# Altium schematic sheet Sheet_1_MCU.SchDoc(Sheet_1_MCU)
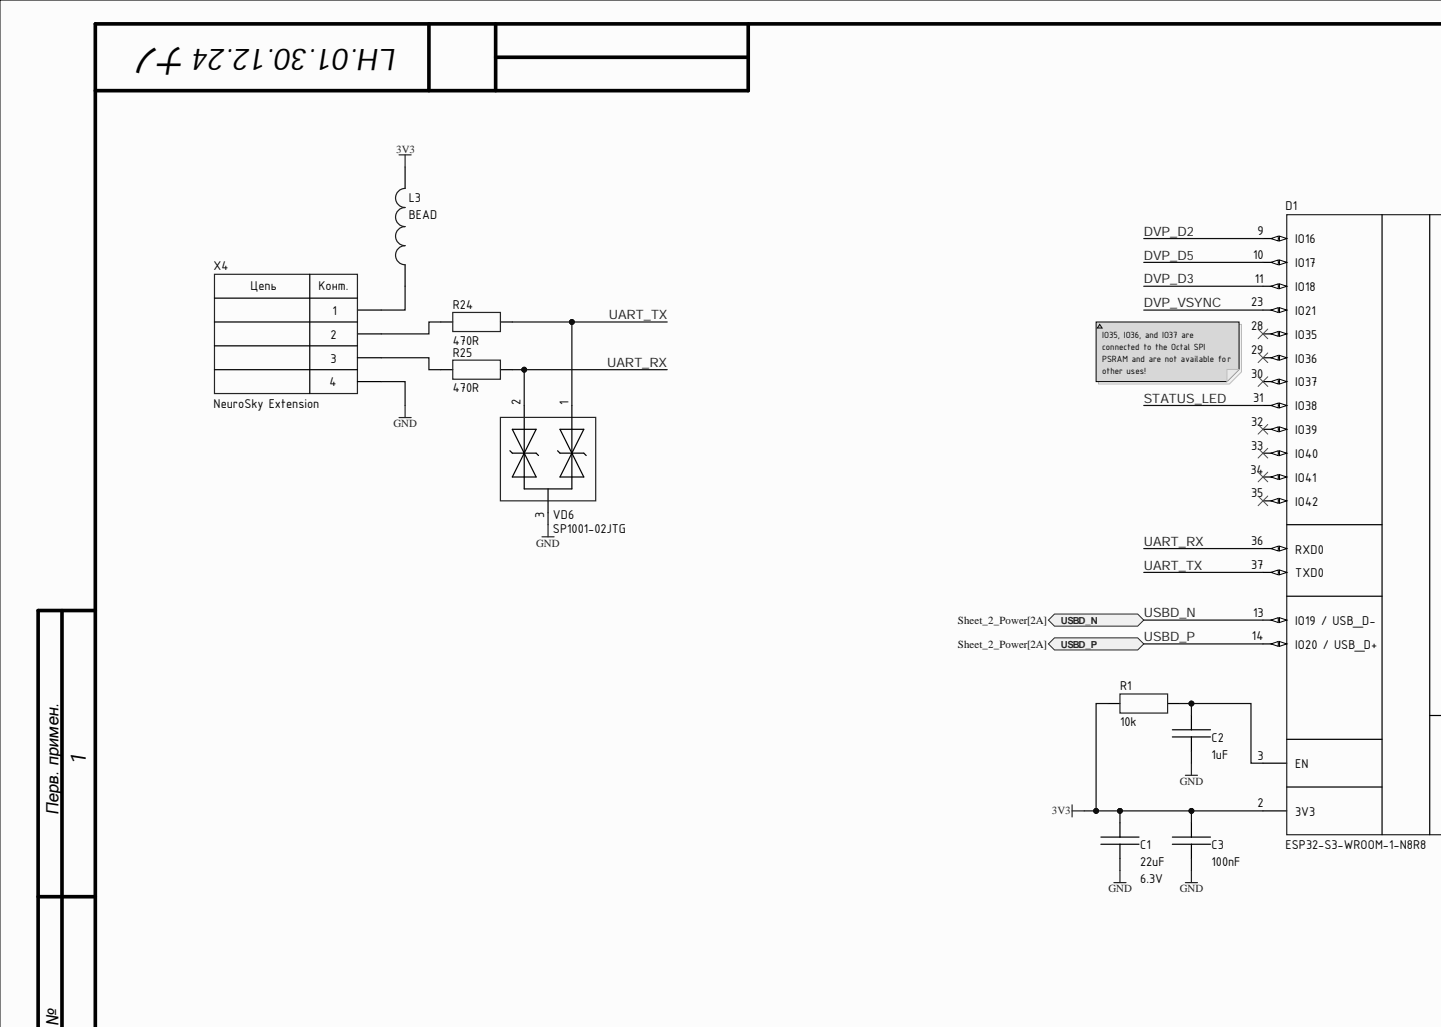
[diagram p0: Sheet_1_MCU.SchDoc, top left]
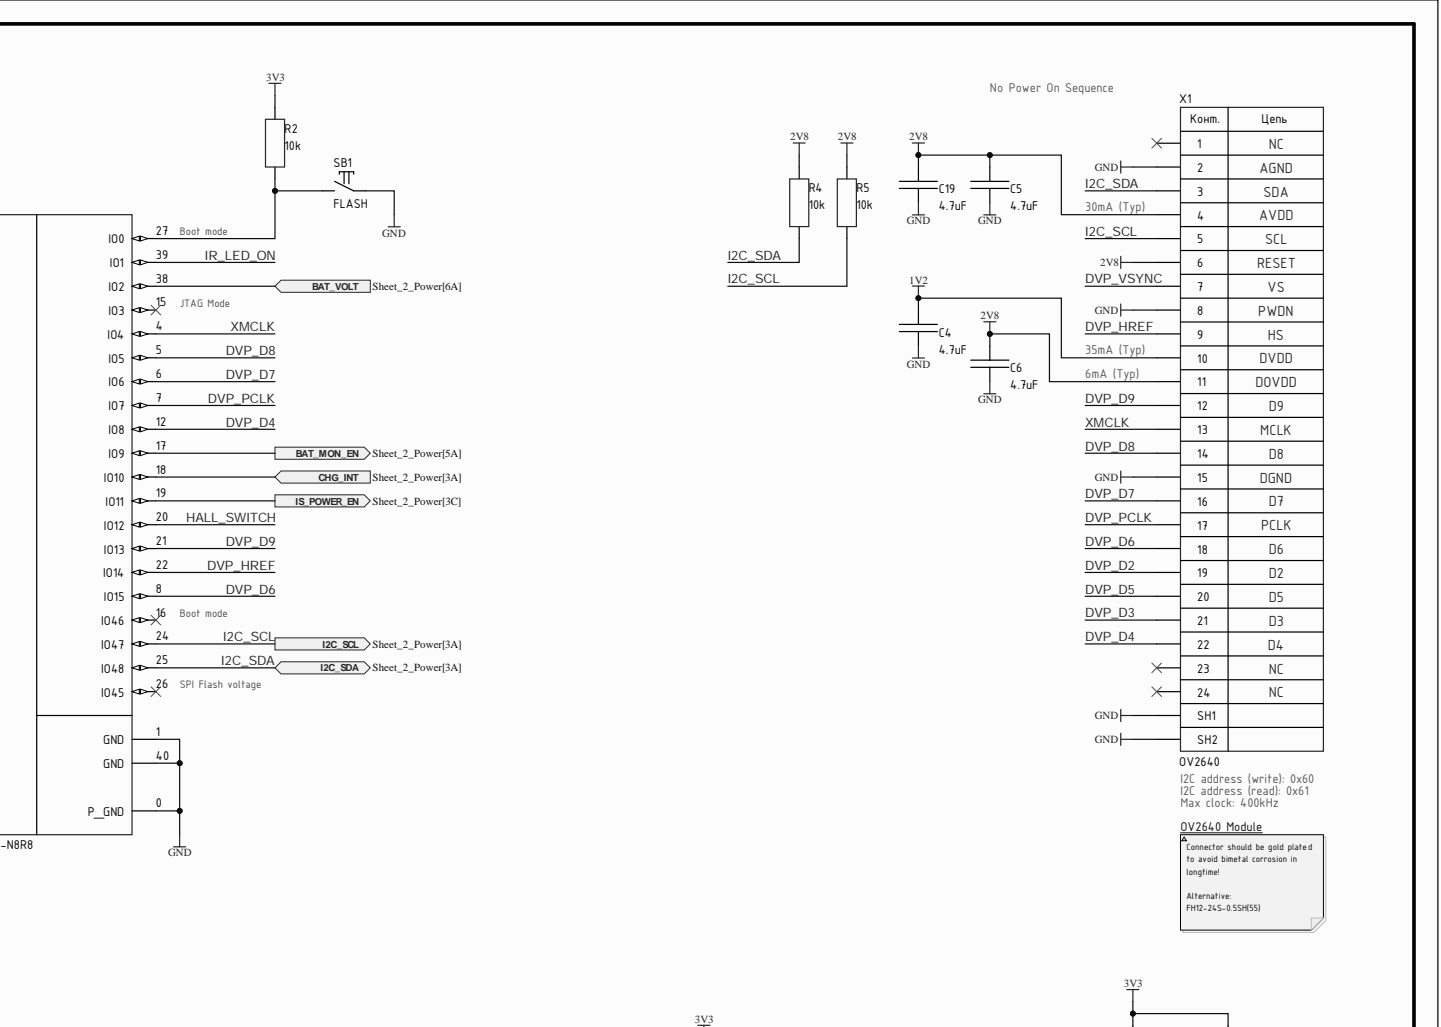
[diagram p1: Sheet_1_MCU.SchDoc, top right]
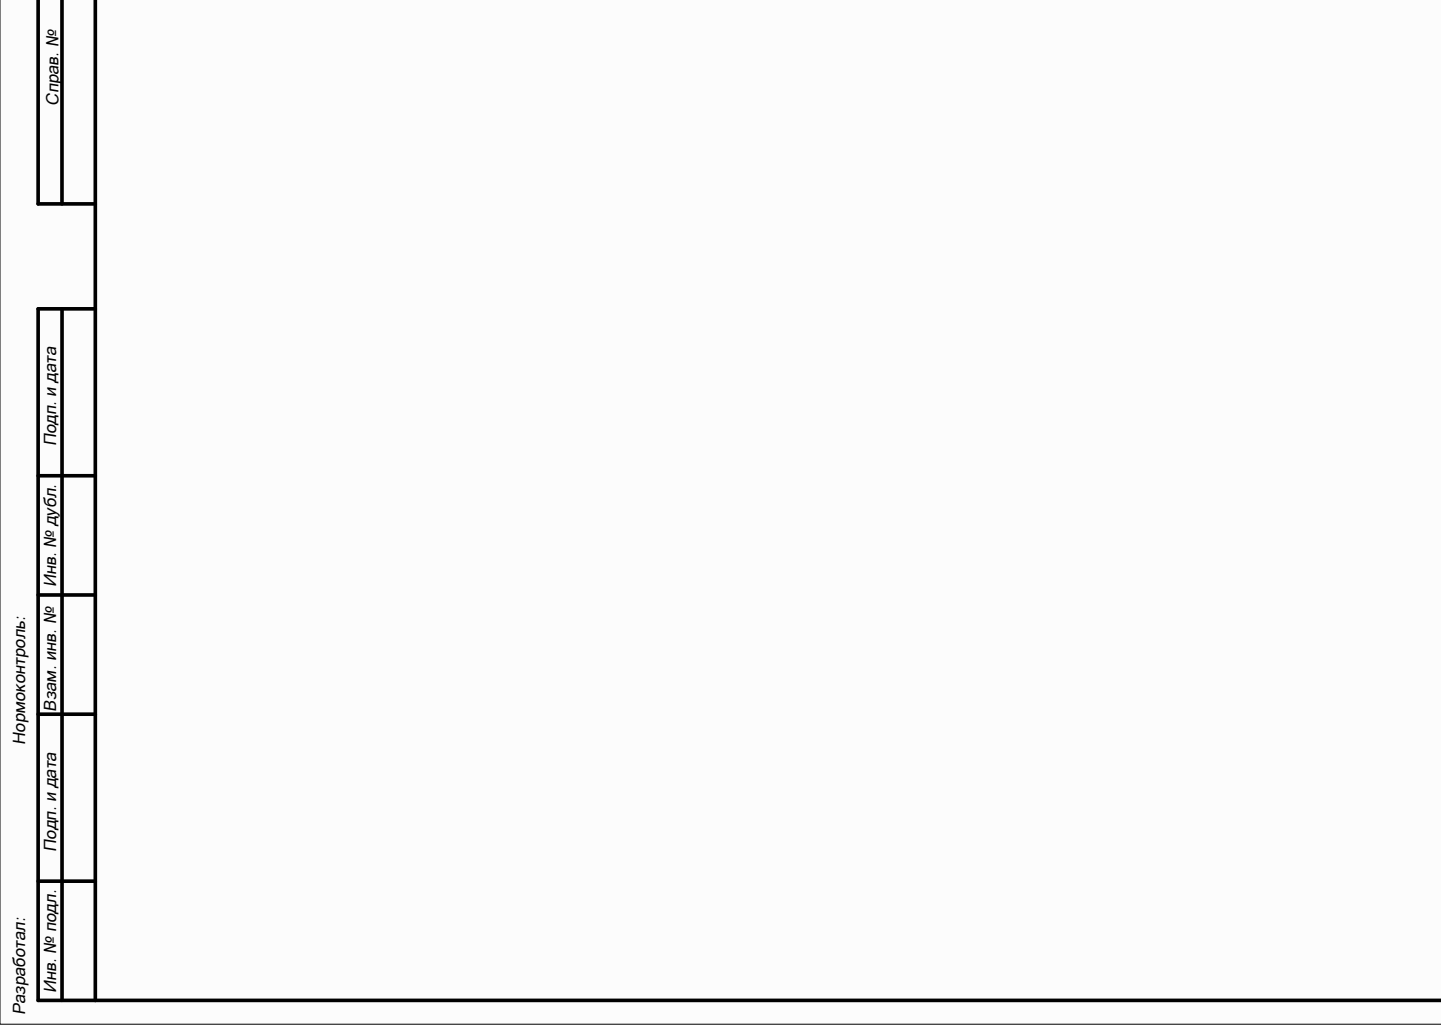
[diagram p2: Sheet_1_MCU.SchDoc, bottom left]
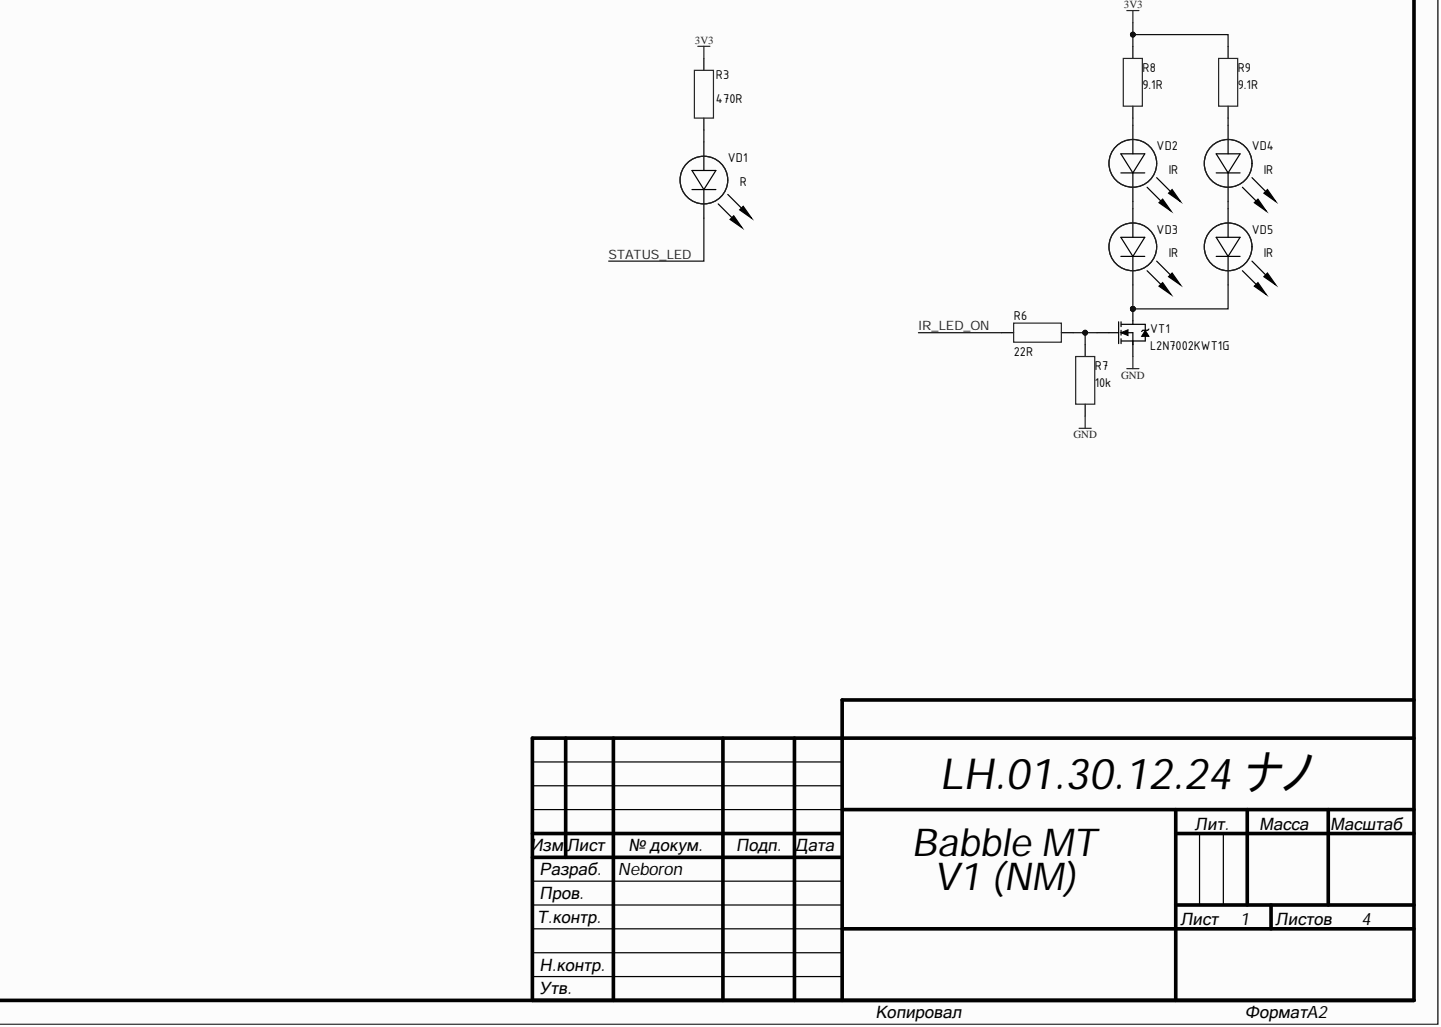
[diagram p3: Sheet_1_MCU.SchDoc, bottom right]

## Parts
C1  value 22uF  Voltage: 6.3V  [CAP: 0603]  (hidden comment: Ceramic capacitor, 22uF, X5R, ±10%, 6.3V, 0603, -55...+125°C)
C2  value 1uF  [CAP: 0402]  (hidden comment: Ceramic capacitor, 1uF, X7R, ±10%, 6.3V, 0402, -55...+125°C)
C3  value 100nF  [CAP: 0402]  (hidden comment: Ceramic capacitor, 100nF, X7R, ±10%, 16V, 0402, -55...+125°C)
C4  value 4.7uF  [CAP: 0402]  (hidden comment: Ceramic capacitor, 4.7uF, X7R, ±10%, 16V, 0402, -55...+125°C)
C5  value 4.7uF  [CAP: 0402]  (hidden comment: Ceramic capacitor, 4.7uF, X7R, ±10%, 16V, 0402, -55...+125°C)
C6  value 4.7uF  [CAP: 0402]  (hidden comment: Ceramic capacitor, 4.7uF, X7R, ±10%, 16V, 0402, -55...+125°C)
C19  value 4.7uF  [CAP: 0402]  (hidden comment: Ceramic capacitor, 4.7uF, X7R, ±10%, 16V, 0402, -55...+125°C)
D1  Wi-Fi module, WiFi 802.11 b/g/n (802.11n up to 150 Mbps) / Bluetooth v4.2 BR/EDR and BLE specification, 32 bit, SD card, UART, SPI, SDIO, I2C, LED PWM, Motor PWM, I2S, IR, pulse counter, GPIO, capacitive touch sensor, ADC, DAC, -40...+85°C, 18x25.5x3.1  [MOD: ESP32-S3-WROOM-1]  (value not drawn)
L3  value BEAD  [IND: 0402]  (hidden comment: Fixed inductor, BEAD, ±25%, 0402, 900mA, -55...+125°C)
R1  value 10k  [RES: 0402]  (hidden comment: Resistor film, 10k, ±5%, 0402, 0.063W, -55...+125°C)
R2  value 10k  [RES: 0402]  (hidden comment: Resistor film, 10k, ±5%, 0402, 0.063W, -55...+125°C)
R3  value 470R  [RES: 0402]  (hidden comment: Resistor film, 470R, ±5%, 0402, 0.063W, -55...+125°C)
R4  value 10k  [RES: 0402]  (hidden comment: Resistor film, 10k, ±5%, 0402, 0.063W, -55...+125°C)
R5  value 10k  [RES: 0402]  (hidden comment: Resistor film, 10k, ±5%, 0402, 0.063W, -55...+125°C)
R6  value 22R  [RES: 0402]  (hidden comment: Resistor film, 22R, ±5%, 0402, 0.063W, -55...+125°C)
R7  value 10k  [RES: 0402]  (hidden comment: Resistor film, 10k, ±5%, 0402, 0.063W, -55...+125°C)
R8  value 9.1R  [RES: 0603]  (hidden comment: Resistor film, 9.1R, ±5%, 0603, 0.1W, -55...+125°C)
R9  value 9.1R  [RES: 0603]  (hidden comment: Resistor film, 9.1R, ±5%, 0603, 0.1W, -55...+125°C)
R24  value 470R  [RES: 0402]  (hidden comment: Resistor film, 470R, ±5%, 0402, 0.063W, -55...+125°C)
R25  value 470R  [RES: 0402]  (hidden comment: Resistor film, 470R, ±5%, 0402, 0.063W, -55...+125°C)
SB1  CommentText: FLASH  [BUT: TS-1186F-B-A]  (hidden comment: Tactile button, TS-1186F)
VD1  Color: R  [LED: 0402R]  (hidden comment: LED, Green, *, *, *, -55...+150°C)
VD2  Color: IR  [LED: 1206R]  (hidden comment: LED, 850...858nm, 35mA, 1.5V, 1206, -55...+150°C)
VD3  Color: IR  [LED: 1206R]  (hidden comment: LED, 850...858nm, 35mA, 1.5V, 1206, -55...+150°C)
VD4  Color: IR  [LED: 1206R]  (hidden comment: LED, 850...858nm, 35mA, 1.5V, 1206, -55...+150°C)
VD5  Color: IR  [LED: 1206R]  (hidden comment: LED, 850...858nm, 35mA, 1.5V, 1206, -55...+150°C)
VD6  PartNumber: SP1001-02JTG  [SC-70]  (hidden comment: TVS, 5.5V, 2A, , , SC-70-3, -55...+150°C)
VT1  PartNumber: L2N7002KWT1G  [SC-70]  (hidden comment: MOSFET N-channel transistor, 60V, 380mA, , 300mW, 2.3Ohm, 34pF, SC-70, -55...+150°C)
X1  CommentText: OV2640  [CON: FFC/FPC-24-0.5(Hirose)]  (hidden comment: Connector, 24pin, 0.5mm, FFC/FPC, 0.5A)
X4  CommentText: NeuroSky Extension  [CON: SM04B-GHS]  (hidden comment: Connector, 4pin, 1.25mm, 50VDC, 1A)

## Ports
BAT_MON_EN, BAT_VOLT, CHG_INT, I2C_SCL, I2C_SDA, IS_POWER_EN, USBD_N, USBD_P

## Nets
1V2: C4.1 X1.10
2V8: C5.1 C6.1 C19.1 R4.1 R5.1 X1.4 X1.6 X1.11
3V3: C1.2 C3.2 D1.2(3V3) L3.1 R1.2 R2.1 R3.2 R8.2 R9.2
GND: C1.1 C2.1 C3.1 C4.2 C5.2 C6.2 C19.2 D1.0(P_GND) D1.1(GND) D1.40(GND) R7.2 SB1.1 VD6.3 VT1.3 X1.2 X1.8 X1.15 X1.SH1 X1.SH2 X4.4
BAT_MON_EN: D1.17(IO9) ; port BAT_MON_EN
BAT_VOLT: D1.38(IO2) ; port BAT_VOLT
CHG_INT: D1.18(IO10) ; port CHG_INT
DVP_D2: D1.9(IO16) X1.19
DVP_D3: D1.11(IO18) X1.21
DVP_D4: D1.12(IO8) X1.22
DVP_D5: D1.10(IO17) X1.20
DVP_D6: D1.8(IO15) X1.18
DVP_D7: D1.6(IO6) X1.16
DVP_D8: D1.5(IO5) X1.14
DVP_D9: D1.21(IO13) X1.12
DVP_HREF: D1.22(IO14) X1.9
DVP_PCLK: D1.7(IO7) X1.17
DVP_VSYNC: D1.23(IO21) X1.7
HALL_SWITCH: D1.20(IO12)
I2C_SCL: D1.24(IO47) R5.2 X1.5 ; port I2C_SCL
I2C_SDA: D1.25(IO48) R4.2 X1.3 ; port I2C_SDA
IR_LED_ON: D1.39(IO1) R6.2
IS_POWER_EN: D1.19(IO11) ; port IS_POWER_EN
STATUS_LED: D1.31(IO38) VD1.1
UART_RX: D1.36(RXD0) R25.1 VD6.2
UART_TX: D1.37(TXD0) R24.1 VD6.1
USBD_N: D1.13(IO19) ; port USBD_N
USBD_P: D1.14(IO20) ; port USBD_P
XMCLK: D1.4(IO4) X1.13
NetC2_2: C2.2 D1.3(EN) R1.1
NetD1_27: D1.27(IO0) R2.2 SB1.2
NetL3_2: L3.2 X4.1
NetR3_1: R3.1 VD1.2
NetR6_1: R6.1 R7.1 VT1.1
NetR8_1: R8.1 VD2.2
NetR9_1: R9.1 VD4.2
NetR24_2: R24.2 X4.2
NetR25_2: R25.2 X4.3
NetVD2_1: VD2.1 VD3.2
NetVD3_1: VD3.1 VD5.1 VT1.2
NetVD4_1: VD4.1 VD5.2

Not connected: D1 15(IO3) 16(IO46) 26(IO45) 28(IO35) 29(IO36) 30(IO37) 32(IO39) 33(IO40) 34(IO41) 35(IO42); X1 1 23 24

## Library data of the parts
C1 = Ceramic_0603: Manufacturer: Any; Component Kind: Standard; Library Name: capacitor.IntLib; Package: 0603; Temperature: -55...+125°C; Tolerance: ±10%; TypeCapacitor: Ceramic capacitor; TypeDielectric: X5R; ValueManufacturerPartNumber: Ceramic capacitor, 22uF, X5R, ±10%, 6.3V, 0603, -55...+125°C
C2-C6 C19 = Ceramic_0402: Manufacturer: Any; Component Kind: Standard; Library Name: capacitor.IntLib; Package: 0402; Temperature: -55...+125°C; Tolerance: ±10%; TypeCapacitor: Ceramic capacitor; TypeDielectric: X7R
  C2: ValueManufacturerPartNumber: Ceramic capacitor, 1uF, X7R, ±10%, 6.3V, 0402, -55...+125°C; Voltage: 6.3V
  C3: ValueManufacturerPartNumber: Ceramic capacitor, 100nF, X7R, ±10%, 16V, 0402, -55...+125°C; Voltage: 16V
  C4-C6 C19: ValueManufacturerPartNumber: Ceramic capacitor, 4.7uF, X7R, ±10%, 16V, 0402, -55...+125°C; Voltage: 16V
D1 = ESP32-S3-WROOM-1-N8R8: Wi-Fi; Manufacturer: Espressif Systems; Core: 32 bit; Component Kind: Standard; ComponentType: Wi-Fi module; HelpURL: https://www.espressif.com/sites/default/files/documentation/esp32-s3-wroom-1_wroom-1u_datasheet_en.pdf; Library Name: module.IntLib; Package: 18x25.5x3.1; PartNumber: ESP32-S3-WROOM-1-N8R8; Temperature: -40...+85°C; TypeInterface: SD card, UART, SPI, SDIO, I2C, LED PWM, Motor PWM, I2S, IR, pulse counter, GPIO, capacitive touch sensor, ADC, DAC; TypeStandart: WiFi 802.11 b/g/n (802.11n up to 150 Mbps) / Bluetooth v4.2 BR/EDR and BLE specification; ValueManufacturerPartNumber: Wi-Fi module, WiFi 802.11 b/g/n (802.11n up to 150 Mbps) / Bluetooth v4.2 BR/EDR and BLE specification, 32 bit, SD card, UART, SPI, SDIO, I2C, LED PWM, Motor PWM, I2S, IR, pulse counter, GPIO, capacitive touch sensor, ADC, DAC, -40...+85°C, 18x25.5x3.1
L3 = Inductor_0402: Manufacturer: Murata Electronics; PartNumber: BLM15PX601SN1D; Component Kind: Standard; HelpURL: https://www.lcsc.com/datasheet/lcsc_datasheet_2304140030_Murata-Electronics-BLM15PX601SN1D_C160977.pdf; Library Name: inductor.IntLib; MaxCurrent: 900mA; Package: 0402; Temperature: -55...+125°C; Tolerance: ±25%; TypeInductor: Fixed inductor; ValueManufacturerPartNumber: Fixed inductor, BEAD, ±25%, 0402, 900mA, -55...+125°C
R1 R2 R4-R7 = Resistor_0402: Manufacturer: Any; Component Kind: Standard; Library Name: resistor.IntLib; Package: 0402; Power: 0.063W; Temperature: -55...+125°C; Tolerance: ±5%; TypeResistor: Resistor film
  R1 R2 R4 R5 R7: ValueManufacturerPartNumber: Resistor film, 10k, ±5%, 0402, 0.063W, -55...+125°C
  R6: ValueManufacturerPartNumber: Resistor film, 22R, ±5%, 0402, 0.063W, -55...+125°C
R3 R24 R25 = Resistor_0402: Manufacturer: Yageo; PartNumber: RC0402JR-07100RL; Component Kind: Standard; HelpURL: https://www.digikey.com/product-detail/en/yageo/RC0402JR-07100RL/311-100JRCT-ND/729362; Library Name: resistor.IntLib; Package: 0402; Power: 0.063W; Temperature: -55...+125°C; Tolerance: ±5%; TypeResistor: Resistor film; ValueManufacturerPartNumber: Resistor film, 470R, ±5%, 0402, 0.063W, -55...+125°C
R8 R9 = Resistor_0603: Manufacturer: Any; Component Kind: Standard; Library Name: resistor.IntLib; Package: 0603; Power: 0.1W; Temperature: -55...+125°C; Tolerance: ±5%; TypeResistor: Resistor film; ValueManufacturerPartNumber: Resistor film, 9.1R, ±5%, 0603, 0.1W, -55...+125°C
SB1 = Button_tactile: Manufacturer: XKB Connection; PartNumber: TS-1186F-C-A; Component Kind: Standard; HelpURL: https://www.lcsc.com/product-detail/Tactile-Switches_XKB-Connection-TS-1186F-C-A_C908471.html; Library Name: electromechanics.IntLib; Package: TS-1186F; TypeButton: Tactile button; ValueManufacturerPartNumber: Tactile button, TS-1186F
VD1 = LED_single: ColorParameter: Green; Component Kind: Standard; Library Name: diode.IntLib; Temperature: -55...+150°C; TypeDiode: LED; ValueManufacturerPartNumber: LED, Green, *, *, *, -55...+150°C
VD2-VD5 = LED_single: Manufacturer: XINGLIGHT; PartNumber: XL-3216HIRC-850; ColorParameter: 850...858nm; Component Kind: Standard; Current: 35mA; HelpURL: https://www.lcsc.com/datasheet/lcsc_datasheet_2409271733_XINGLIGHT-XL-3216HIRC-850_C965891.pdf; Library Name: diode.IntLib; Package: 1206; Temperature: -55...+150°C; TypeDiode: LED; ValueManufacturerPartNumber: LED, 850...858nm, 35mA, 1.5V, 1206, -55...+150°C; VoltageDrop: 1.5V
VD6 = TVS_array: Manufacturer: Littelfuse; Component Kind: Standard; Current: 2A; HelpURL: https://www.lcsc.com/datasheet/lcsc_datasheet_2410010304_Littelfuse-SP1001-02JTG_C151300.pdf; Library Name: diode.IntLib; Package: SC-70-3; Temperature: -55...+150°C; TypeDiode: TVS; ValueManufacturerPartNumber: TVS, 5.5V, 2A, , , SC-70-3, -55...+150°C; Voltage: 5.5V
VT1 = MOSFET_N_123: Manufacturer: LRC; Component Kind: Standard; CurrentDS: 380mA; HelpURL: https://www.lcsc.com/datasheet/lcsc_datasheet_2111041830_LRC-L2N7002KWT1G_C2912011.pdf; InputCapacitor: 34pF; Library Name: transistor.IntLib; MaxPower: 300mW; Package: SC-70; RDS: 2.3Ohm; Temperature: -55...+150°C; TypeTransistor: MOSFET N-channel transistor; ValueManufacturerPartNumber: MOSFET N-channel transistor, 60V, 380mA, , 300mW, 2.3Ohm, 34pF, SC-70, -55...+150°C; VoltageDS: 60V
X1 = Connector_FFC_24 (TF31-24S-0.5SH(800)): Manufacturer: Hirose; Component Kind: Standard; CurrentMax: 0.5A; HelpURL: https://www.lcsc.com/datasheet/lcsc_datasheet_2410291517_HRS-Hirose-TF31-24S-0-5SH-800_C3169854.pdf; Library Name: connector.IntLib; Multichannel: 24pin; PartNumber: TF31-24S-0.5SH(800); Pitch: 0.5mm; TypeConnector: Connector; ValueManufacturerPartNumber: Connector, 24pin, 0.5mm, FFC/FPC, 0.5A; VoltageMax: FFC/FPC
X4 = Connector_SM04B: Manufacturer: JST; PartNumber: SM04B-GHS; Component Kind: Standard; CurrentMax: 1A; HelpURL: https://www.digikey.com/product-detail/en/jst-sales-america-inc/SM04B-GHS-TB-LF-SN/455-1566-1-ND/807834; Library Name: connector.IntLib; Multichannel: 4pin; Pitch: 1.25mm; TypeConnector: Connector; ValueManufacturerPartNumber: Connector, 4pin, 1.25mm, 50VDC, 1A; VoltageMax: 50VDC
